# Altium schematic sheet RemoteControl.SchDoc(RemoteControl)
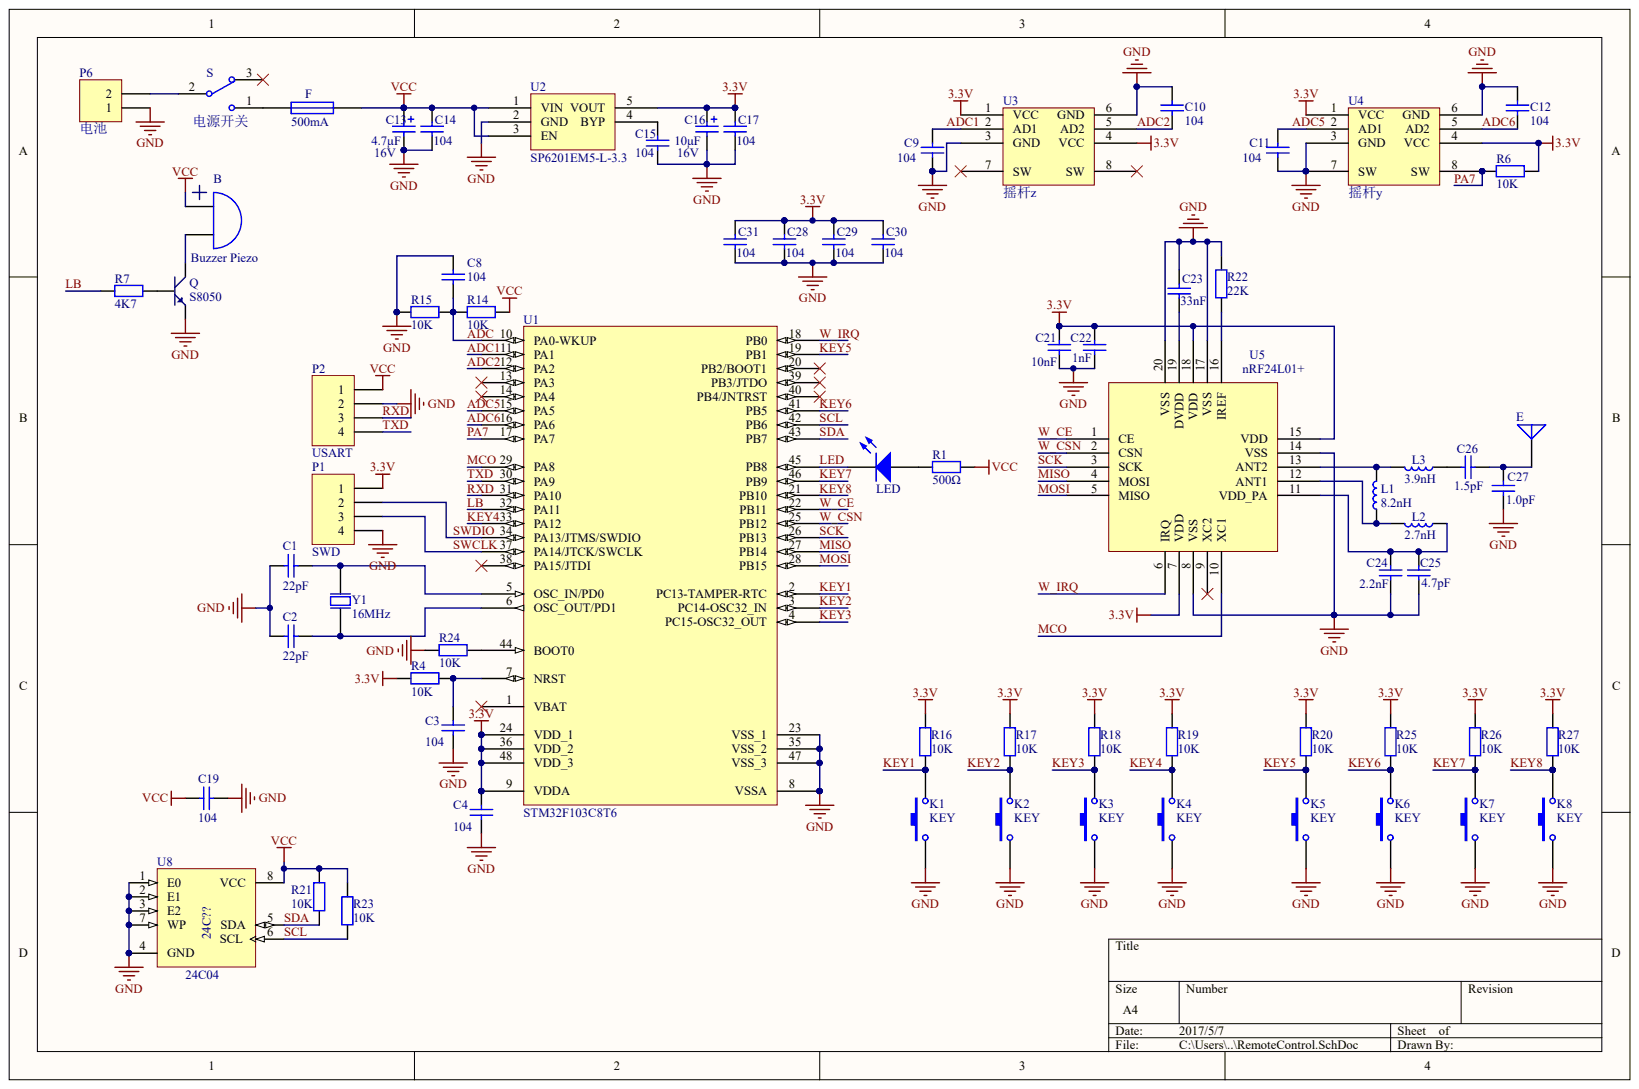
[diagram p0: RemoteControl.SchDoc]

## Parts
B  Buzzer Piezo
C1  22pF
C2  22pF
C3  104
C4  104
C8  104
C9  104
C10  104
C11  104
C12  104
C13  4.7μF  Voltage: 16V
C14  104
C15  104
C16  10μF  Voltage: 16V
C17  104
C19  104
C21  10nF
C22  1nF
C23  33nF
C24  2.2nF
C25  4.7pF
C26  1.5pF
C27  1.0pF
C28  104
C29  104
C30  104
C31  104
E  (no value)
F  500mA
K1  KEY
K2  KEY
K3  KEY
K4  KEY
K5  KEY
K6  KEY
K7  KEY
K8  KEY
L1  8.2nH
L2  2.7nH
L3  3.9nH
LED  LED Chip  (value not drawn)
P1  SWD
P2  USART
P6  电池
Q  S8050
R1  500Ω
R4  10K
R6  10K
R7  value 4K7  (hidden comment: 10K)
R14  10K
R15  10K
R16  10K
R17  10K
R18  10K
R19  10K
R20  10K
R21  10K
R22  22K
R23  10K
R24  10K
R25  10K
R26  10K
R27  10K
S  电源开关
U1  STM32F103C8T6
U2  SP6201EM5-L-3.3
U3  摇杆z
U4  摇杆y
U5  nRF24L01+
U8  24C04
Y1  Frequency: 16MHz  (hidden comment: 8MHz)

## Nets
3.3V: C4.2 C16.2 C17.2 C21.1 C22.1 C28.2 C29.2 C30.2 C31.2 P1.1 R4.1 R6.1 R16.2 R17.2 R18.2 R19.2 R20.2 R25.2 R26.2 R27.2 U1.9(VDDA) U1.24(VDD_1) U1.36(VDD_2) U1.48(VDD_3) U2.5(VOUT) U3.1(VCC) U3.4(VCC) U4.1(VCC) U4.4(VCC) U5.7(VDD) U5.15(VDD) U5.18(VDD)
GND: C1.1 C2.1 C3.1 C4.1 C8.1 C9.1 C10.2 C11.1 C12.2 C13.1 C14.1 C15.1 C16.1 C17.1 C19.2 C21.2 C22.2 C23.1 C24.2 C25.2 C27.1 C28.1 C29.1 C30.1 C31.1 K1.1 K2.1 K3.1 K4.1 K5.1 K6.1 K7.1 K8.1 P1.4 P2.2 P6.1 Q.1 R15.2 R22.2 R24.2 U1.8(VSSA) U1.23(VSS_1) U1.35(VSS_2) U1.47(VSS_3) U2.2(GND) U3.3(GND) U3.6(GND) U4.3(GND) U4.6(GND) U4.7(SW) U5.8(VSS) U5.14(VSS) U5.17(VSS) U5.20(VSS) U8.1(E0) U8.2(E1) U8.3(E2) U8.4(GND) U8.7(WP)
VCC: B.1 C13.2 C14.2 C19.1 F.2 P2.1 R1.1 R14.1 R21.2 R23.2 U2.1(VIN) U2.3(EN) U8.8(VCC)
ADC: C8.2 R14.2 R15.1 U1.10(PA0-WKUP)
ADC1: C9.2 U1.11(PA1) U3.2(AD1)
ADC2: C10.1 U1.12(PA2) U3.5(AD2)
ADC5: C11.2 U1.15(PA5) U4.2(AD1)
ADC6: C12.1 U1.16(PA6) U4.5(AD2)
KEY1: K1.2 R16.1 U1.2(PC13-TAMPER-RTC)
KEY2: K2.2 R17.1 U1.3(PC14-OSC32_IN)
KEY3: K3.2 R18.1 U1.4(PC15-OSC32_OUT)
KEY4: K4.2 R19.1 U1.33(PA12)
KEY5: K5.2 R20.1 U1.19(PB1)
KEY6: K6.2 R25.1 U1.41(PB5)
KEY7: K7.2 R26.1 U1.46(PB9)
KEY8: K8.2 R27.1 U1.21(PB10)
LB: R7.2 U1.32(PA11)
LED: LED.2 U1.45(PB8)
MCO: U1.29(PA8) U5.10(XC1)
MISO: U1.27(PB14) U5.4(MOSI)
MOSI: U1.28(PB15) U5.5(MISO)
PA7: R6.2 U1.17(PA7) U4.8(SW)
RXD: P2.3 U1.31(PA10)
SCK: U1.26(PB13) U5.3(SCK)
SCL: R23.1 U1.42(PB6) U8.6(SCL)
SDA: R21.1 U1.43(PB7) U8.5(SDA)
SWCLK: P1.3 U1.37(PA14/JTCK/SWCLK)
SWDIO: P1.2 U1.34(PA13/JTMS/SWDIO)
TXD: P2.4 U1.30(PA9)
W_CE: U1.22(PB11) U5.1(CE)
W_CSN: U1.25(PB12) U5.2(CSN)
W_IRQ: U1.18(PB0) U5.6(IRQ)
NetB_2: B.2 Q.3
NetC1_2: C1.2 U1.5(OSC_IN/PD0) Y1.2
NetC2_2: C2.2 U1.6(OSC_OUT/PD1) Y1.1
NetC3_2: C3.2 R4.2 U1.7(NRST)
NetC15_2: C15.2 U2.4(BYP)
NetC23_2: C23.2 U5.19(DVDD)
NetC24_1: C24.1 C25.1 L2.1 U5.11(VDD_PA)
NetC26_1: C26.1 L3.1
NetC26_2: C26.2 C27.2 E.1
NetF_1: F.1 S.1
NetL1_1: L1.1 L2.2 U5.12(ANT1)
NetL1_2: L1.2 L3.2 U5.13(ANT2)
NetLED_1: LED.1 R1.2
NetP6_2: P6.2 S.2
NetQ_2: Q.2 R7.1
NetR22_1: R22.1 U5.16(IREF)
NetR24_1: R24.1 U1.44(BOOT0)

Not connected: S 3; U1 1(VBAT) 13(PA3) 14(PA4) 20(PB2/BOOT1) 38(PA15/JTDI) 39(PB3/JTDO) 40(PB4/JNTRST); U3 7(SW) 8(SW); U5 9(XC2)

## Library data of the parts
L1-L3 = (no symbol name): Code_IEC: 1005; Published: 8-Jun-2000; LatestRevisionDate: 29-May-2009; LatestRevisionNote: IPC-7351 Footprint Added.; PackageReference: 0402-A; PackageDescription: Chip Inductor; Code_JEITA: 0402; Publisher: Altium Limited
  L1: Value: 8.2nH
  L2: Value: 2.7nH
  L3: Value: 3.9nH
B C1-C4 C8-C17 C19 C21-C31 E F K1-K8 LED P1 P2 P6 Q R1 R4 R6 R7 R14-R27 S U1-U5 U8 Y1 = (no symbol name)
  B: Published: 24-Mar-1999; LatestRevisionDate: 17-Jul-2002; LatestRevisionNote: Re-released for DXP Platform.; PackageReference: PIN2
  C1 C2: Published: 8-Jun-2000; LatestRevisionDate: 17-Jul-2002; LatestRevisionNote: Re-released for DXP Platform.; PackageReference: RAD-0.3; PackageDescription: Radial Cap, Thru-Hole; 2 Leads; 0.3 in Pin Spacing; Value: 22pF
  C3 C4 C8-C12 C14 C15 C17 C28-C31: Published: 8-Jun-2000; LatestRevisionDate: 17-Jul-2002; LatestRevisionNote: Re-released for DXP Platform.; PackageReference: RAD-0.3; PackageDescription: Radial Cap, Thru-Hole; 2 Leads; 0.3 in Pin Spacing; Value: 104
  C13: Published: 8-Jun-2000; Revision: July-2002: Re-released for DXP Platform.; Value: 4.7μF
  C16: Published: 8-Jun-2000; Revision: July-2002: Re-released for DXP Platform.; Value: 10μF
  C19 F LED R7 R21 R23 R24 Y1: Published: 8-Jun-2000; Revision: July-2002: Re-released for DXP Platform.
  C21: Published: 8-Jun-2000; LatestRevisionDate: 17-Jul-2002; LatestRevisionNote: Re-released for DXP Platform.; PackageReference: RAD-0.3; PackageDescription: Radial Cap, Thru-Hole; 2 Leads; 0.3 in Pin Spacing; Value: 10nF
  C22: Published: 8-Jun-2000; LatestRevisionDate: 17-Jul-2002; LatestRevisionNote: Re-released for DXP Platform.; PackageReference: RAD-0.3; PackageDescription: Radial Cap, Thru-Hole; 2 Leads; 0.3 in Pin Spacing; Value: 1nF
  C23: Published: 8-Jun-2000; LatestRevisionDate: 17-Jul-2002; LatestRevisionNote: Re-released for DXP Platform.; PackageReference: RAD-0.3; PackageDescription: Radial Cap, Thru-Hole; 2 Leads; 0.3 in Pin Spacing; Value: 33nF
  C24: Published: 8-Jun-2000; LatestRevisionDate: 17-Jul-2002; LatestRevisionNote: Re-released for DXP Platform.; PackageReference: RAD-0.3; PackageDescription: Radial Cap, Thru-Hole; 2 Leads; 0.3 in Pin Spacing; Value: 2.2nF
  C25: Published: 8-Jun-2000; LatestRevisionDate: 17-Jul-2002; LatestRevisionNote: Re-released for DXP Platform.; PackageReference: RAD-0.3; PackageDescription: Radial Cap, Thru-Hole; 2 Leads; 0.3 in Pin Spacing; Value: 4.7pF
  C26: Published: 8-Jun-2000; LatestRevisionDate: 17-Jul-2002; LatestRevisionNote: Re-released for DXP Platform.; PackageReference: RAD-0.3; PackageDescription: Radial Cap, Thru-Hole; 2 Leads; 0.3 in Pin Spacing; Value: 1.5pF
  C27: Published: 8-Jun-2000; LatestRevisionDate: 17-Jul-2002; LatestRevisionNote: Re-released for DXP Platform.; PackageReference: RAD-0.3; PackageDescription: Radial Cap, Thru-Hole; 2 Leads; 0.3 in Pin Spacing; Value: 1.0pF
  E: Published: 24-Mar-1999; LatestRevisionDate: 17-Jul-2002; LatestRevisionNote: Re-released for DXP Platform.; PackageReference: PIN1
  P1 P2 P6: LatestRevisionDate: 17-Jul-2002; LatestRevisionNote: Re-released for DXP Platform.
  R1: Published: 8-Jun-2000; Revision: July-2002: Re-released for DXP Platform.; Value: 500Ω
  R4 R6 R14-R20 R25-R27: Published: 8-Jun-2000; Revision: July-2002: Re-released for DXP Platform.; Value: 10K
  R22: Published: 8-Jun-2000; Revision: July-2002: Re-released for DXP Platform.; Value: 22K
  S: Published: 24-Mar-1999; LatestRevisionDate: 17-Dec-2003; LatestRevisionNote: Schematic revised, PCB Footprint replaced.; DatasheetVersion: 05-Dec-2000; PackageReference: TL36WW15050; PackageDescription: Switch; 4 Leads; ComponentLink1Description: Manufacturer Link; ComponentLink1URL: http://www.apem.com
  U1: Published: *; DatasheetVersion: *; PackageVersion: *; PackageReference: *; PackageDescription: *; ComponentLink1Description: *; ComponentLink1URL: *; ComponentLink2Description: *; ComponentLink2URL: *; Publisher: *
  U8: Published: 24-Aug-2001; LatestRevisionDate: 13-Apr-2006; LatestRevisionNote: IPC-7351 Footprint Added.; DatasheetVersion: Sep-1999; PackageVersion: Jul-1999; PackageReference: SOP8; PackageDescription: 8-Pin Small Outline Integrated Circuit 1.27 mm Pitch; ComponentLink1Description: Manufacturer Link; ComponentLink1URL: http://www.st.com/; ComponentLink2Description: Datasheet; ComponentLink2URL: http://www.st.com/stonline/products/literature/ds/5067/m24c01-r.pdf
  B C1-C4 C8-C17 C19 C21-C31 E F LED P1 P2 P6 R1 R4 R6 R7 R14-R27 S U8 Y1: Publisher: Altium Limited
